# Altium schematic sheet ESSICC-GET-NMI.SchDoc(ESSICC-GET-NMI)
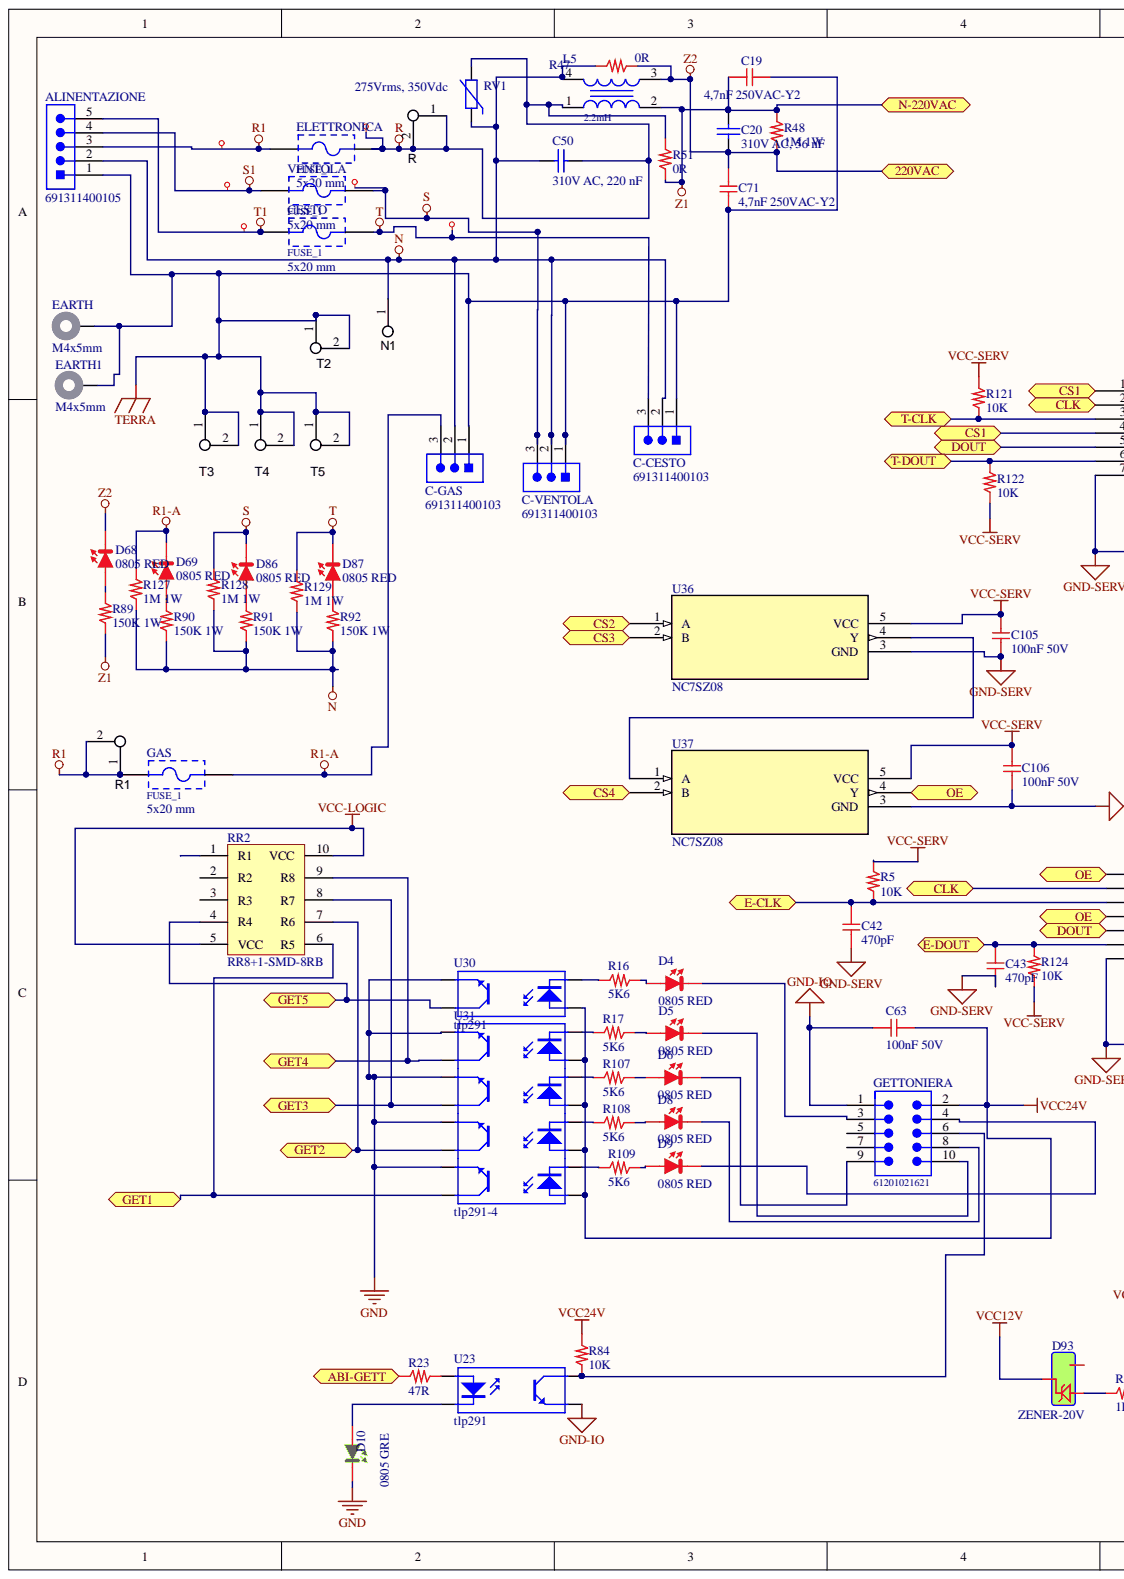
[diagram p0: ESSICC-GET-NMI.SchDoc, left]
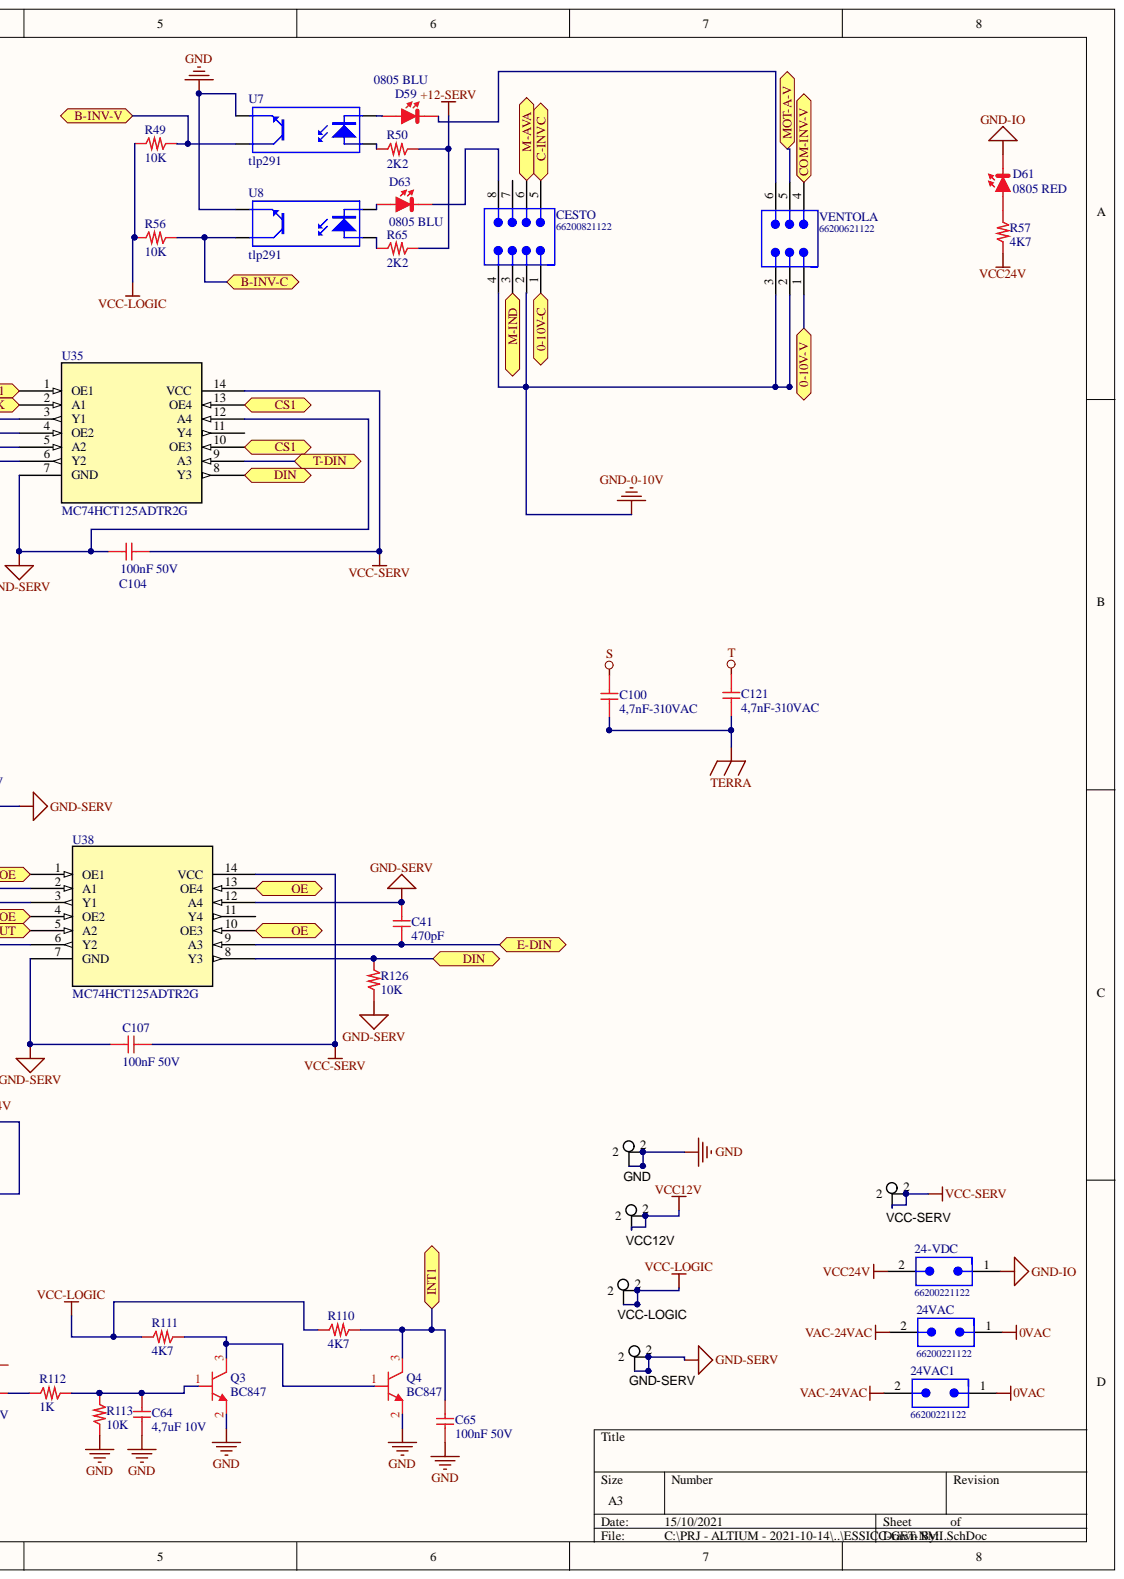
[diagram p1: ESSICC-GET-NMI.SchDoc, right]

## Parts
24-VDC  66200221122  [66200221122]
24VAC  66200221122  [66200221122]
24VAC1  66200221122  [66200221122]
ALINENTAZIONE  691311400105  [691311400105]
C19  4,7nF 250VAC-Y2  [WE-VD_10mm_820412511]
C20  310V AC, 56 nF  value 56 nF  [WCAP-FTXX_13x5x10_CS]
C41  470pF  [0603_c]
C42  470pF  [0603_c]
C43  470pF  [0603_c]
C50  310V AC, 220 nF  value 220 nF  [WCAP-FTXX_15x7x12.5_CS]
C63  100nF 50V  [0603_c]
C64  4,7uF 10V  [0603_c]
C65  100nF 50V  [0603_c]
C71  4,7nF 250VAC-Y2  [WE-VD_10mm_820412511]
C100  4,7nF-310VAC  [CY-PASSO15,24]
C104  100nF 50V  [0603_c]
C105  100nF 50V  [0603_c]
C106  100nF 50V  [0603_c]
C107  100nF 50V  [0603_c]
C121  4,7nF-310VAC  [CY-PASSO15,24]
C-CESTO  691311400103  [691311400103]
C-GAS  691311400103  [691311400103]
C-VENTOLA  691311400103  [691311400103]
CESTO  66200821122  [66200821122]
CESTO  FUSE_1  Fuse Size: 5x20 mm  [696108003002]
D4  0805 RED  [0805_Red]
D5  0805 RED  [0805_Red]
D6  0805 RED  [0805_Red]
D8  0805 RED  [0805_Red]
D9  0805 RED  [0805_Red]
D10  0805 GRE  [0805_Green]
D59  0805 BLU  [0805_Red]
D61  0805 RED  [0805_Red]
D63  0805 BLU  [0805_Red]
D68  0805 RED  [0805_Red]
D69  0805 RED  [0805_Red]
D86  0805 RED  [0805_Red]
D87  0805 RED  [0805_Red]
D93  ZENER-20V  [SOT23]
EARTH  M4x5mm  [9774050482]
EARTH1  M4x5mm  [9774050482]
ELETTRONICA  FUSE_1  [696108003002]
GAS  FUSE_1  Fuse Size: 5x20 mm  [696108003002]
GETTONIERA  61201021621  [61201021621]
GND  5012  [Keystone5012]  (value not drawn)
GND-SERV  5012  [Keystone5012]  (value not drawn)
L5  2.2mH  [WE-LF_Type SH]
N1  5012  [FASTOM-TERRA]  (value not drawn)
Q3  BC847  [SOT23]
Q4  BC847  [SOT23]
R  5012  [FASTOM-TERRA]  (value not drawn)
R1  5012  [FASTOM-TERRA]  (value not drawn)
R5  10K  [0603_R]
R16  5K6  [1206_R]
R17  5K6  [1206_R]
R23  47R  [0603_R]
R47  0R  [2512_R_Sens]
R48  1M 1W  [2512_R]
R49  10K  [0603_R]
R50  2K2  [1206_R]
R51  0R  [2512_R_Sens]
R56  10K  [0603_R]
R57  4K7  [1206_R]
R65  2K2  [1206_R]
R84  10K  [0603_R]
R89  150K 1W  [2512_R]
R90  150K 1W  [2512_R]
R91  150K 1W  [2512_R]
R92  150K 1W  [2512_R]
R107  5K6  [1206_R]
R108  5K6  [1206_R]
R109  5K6  [1206_R]
R110  4K7  [0603_R]
R111  4K7  [0603_R]
R112  1K  [0603_R]
R113  10K  [0603_R]
R121  10K  [0603_R]
R122  10K  [0603_R]
R124  10K  [0603_R]
R126  10K  [0603_R]
R127  1M 1W  [2512_R]
R128  1M 1W  [2512_R]
R129  1M 1W  [2512_R]
RR2  RR8+1-SMD-8RB  [RR8+1-SMD]
RV1  275Vrms, 350Vdc  DC Operating Voltage: 350Vdc  [WE-VD_7mm_820572711]
T2  5012  [FASTOM-TERRA]  (value not drawn)
T3  T  [FASTOM-TERRA]
T4  5012  [FASTOM-TERRA]  (value not drawn)
T5  5012  [FASTOM-TERRA]  (value not drawn)
U7  tlp291  [SO4_N]
U8  tlp291  [SO4_N]
U23  tlp291  [SO4_N]
U30  tlp291  [SO4_N]
U31  tlp291-4  [SO16_NO]
U35  MC74HCT125ADTR2G  [TSSOP14_4P50X5P10_ONS-M]
U36  NC7SZ08  [DBV5]
U37  NC7SZ08  [DBV5]
U38  MC74HCT125ADTR2G  [TSSOP14_4P50X5P10_ONS-M]
VCC12V  5012  [Keystone5012]  (value not drawn)
VCC-LOGIC  5012  [Keystone5012]  (value not drawn)
VCC-SERV  5012  [Keystone5012]  (value not drawn)
VENTOLA  66200621122  [66200621122]
VENTOLA  FUSE_1  Fuse Size: 5x20 mm  [696108003002]

## Ports
0-10V-C, 0-10V-V, 220VAC, ABI-GETT, B-INV-C, B-INV-V, C-INVC, CLK, COM-INV-V, CS1, CS2, CS3, CS4, DIN, DOUT, E-CLK, E-DIN, E-DOUT, GET1, GET2, GET3, GET4, GET5, INT1, M-AVA, M-IND, MOT-A-V, N-220VAC, OE, T-CLK, T-DIN, T-DOUT

## Nets
0VAC: 24VAC.1 24VAC1.1
+12-SERV: R50.2 R65.2
GND: C64.2 C65.2 D10.K GND.1 GND.2 Q3.2 Q4.2 R113.2 U7.3 U8.3 U30.3 U31.9 U31.11 U31.13 U31.15
GND-0-10V: CESTO.2 CESTO.4 VENTOLA.2 VENTOLA.3
GND-IO: 24-VDC.1 C63.1 D61.K GETTONIERA.1 U23.3
GND-SERV: C41.1 C42.2 C43.2 C104.1 C105.1 C106.1 C107.1 GND-SERV.1 GND-SERV.2 R126.2 U35.7(GND) U35.12(A4) U36.3(GND) U37.3(GND) U38.7(GND) U38.12(A4)
N: ALINENTAZIONE.2 C50.2 C-CESTO.2 C-GAS.2 C-VENTOLA.2 L5.4 N1.1 R47.2 R90.1 R91.1 R92.1 R127.2 R128.2 R129.2 RV1.1
R: C50.1 ELETTRONICA.2 L5.1 R.1 R.2 R51.1 RV1.2
R1: ALINENTAZIONE.3 ELETTRONICA.1 GAS.1 R1.1 R1.2
R1-A: C-GAS.3 D69.K GAS.2 R127.1
S: C100.1 C-VENTOLA.3 D86.K R128.1 VENTOLA.2
S1: ALINENTAZIONE.4 VENTOLA.1
T: C121.1 C-CESTO.3 CESTO.2 D87.K R129.1
T1: ALINENTAZIONE.5 CESTO.1
TERRA: ALINENTAZIONE.1 C19.2 C71.1 C100.2 C121.2 C-CESTO.1 C-GAS.1 C-VENTOLA.1 EARTH.1 EARTH1.1 T2.1 T2.2 T3.1 T3.2 T4.1 T4.2 T5.1 T5.2
VAC-24VAC: 24VAC.2 24VAC1.2
VCC12V: D93.3 VCC12V.1 VCC12V.2
VCC24V: 24-VDC.2 C63.2 GETTONIERA.2 R57.1 R84.1 U30.1 U31.1 U31.3 U31.5 U31.7
VCC-LOGIC: R49.1 R56.1 R110.2 R111.2 RR2.5(VCC) RR2.10(VCC) VCC-LOGIC.1 VCC-LOGIC.2
VCC-SERV: C104.2 C105.2 C106.2 C107.2 R5.1 R121.1 R122.2 R124.2 U35.14(VCC) U36.5(VCC) U37.5(VCC) U38.14(VCC) VCC-SERV.1 VCC-SERV.2
0-10V-C: CESTO.1 ; port 0-10V-C
0-10V-V: VENTOLA.1 ; port 0-10V-V
ABI-GETT: R23.1 ; port ABI-GETT
B-INV-C: R56.2 U8.4 ; port B-INV-C
B-INV-V: R49.2 U7.4 ; port B-INV-V
C-INVC: CESTO.5 ; port C-INVC
CLK: U35.2(A1) U38.2(A1) ; port CLK
COM-INV-V: VENTOLA.4 ; port COM-INV-V
CS1: U35.1(OE1) U35.4(OE2) U35.10(OE3) U35.13(OE4) ; port CS1
CS2: U36.1(A) ; port CS2
CS3: U36.2(B) ; port CS3
CS4: U37.2(B) ; port CS4
DIN: R126.1 U35.8(Y3) U38.8(Y3) ; port DIN
DOUT: U35.5(A2) U38.5(A2) ; port DOUT
E-CLK: C42.1 R5.2 U38.3(Y1) ; port E-CLK
E-DIN: C41.2 U38.9(A3) ; port E-DIN
E-DOUT: C43.1 R124.1 U38.6(Y2) ; port E-DOUT
GET1: RR2.6(R5) U31.16 ; port GET1
GET2: RR2.7(R6) U31.14 ; port GET2
GET3: RR2.8(R7) U31.12 ; port GET3
GET4: RR2.9(R8) U31.10 ; port GET4
GET5: RR2.4(R4) U30.4 ; port GET5
INT1: C65.1 Q4.3 R110.1 ; port INT1
M-AVA: CESTO.6 ; port M-AVA
M-IND: CESTO.3 ; port M-IND
MOT-A-V: VENTOLA.5 ; port MOT-A-V
OE: U37.4(Y) U38.1(OE1) U38.4(OE2) U38.10(OE3) U38.13(OE4) ; port OE
T-CLK: R121.2 U35.3(Y1) ; port T-CLK
T-DIN: U35.9(A3) ; port T-DIN
T-DOUT: R122.1 U35.6(Y2) ; port T-DOUT
Z1: C19.1 C20.1 L5.2 R48.1 R51.2 R89.1 ; port N-220VAC
Z2: C20.2 C71.2 D68.K L5.3 R47.1 R48.2 ; port 220VAC
NetC64_1: C64.1 Q3.1 R112.1 R113.1
NetCESTO_8: CESTO.8 D63.K
NetD4_A: D4.A R16.2
NetD4_K: D4.K GETTONIERA.3
NetD5_A: D5.A R17.2
NetD5_K: D5.K GETTONIERA.10
NetD6_A: D6.A R107.2
NetD6_K: D6.K GETTONIERA.9
NetD8_A: D8.A R108.2
NetD8_K: D8.K GETTONIERA.8
NetD9_A: D9.A R109.2
NetD9_K: D9.K GETTONIERA.4
NetD10_A: D10.A U23.2
NetD59_A: D59.A U7.2
NetD59_K: D59.K VENTOLA.6
NetD61_A: D61.A R57.2
NetD63_A: D63.A U8.2
NetD68_A: D68.A R89.2
NetD69_A: D69.A R90.2
NetD86_A: D86.A R91.2
NetD87_A: D87.A R92.2
NetD93_1: D93.1 R112.2
NetGETTONIERA_6: GETTONIERA.6 R84.2 U23.4
NetQ3_3: Q3.3 Q4.1 R111.1
NetR16_1: R16.1 U30.2
NetR17_1: R17.1 U31.8
NetR23_2: R23.2 U23.1
NetR50_1: R50.1 U7.1
NetR65_1: R65.1 U8.1
NetR107_1: R107.1 U31.6
NetR108_1: R108.1 U31.4
NetR109_1: R109.1 U31.2
NetU36_4: U36.4(Y) U37.1(A)

Not connected: CESTO 7; D93 2; GETTONIERA 5 7; RR2 1(R1) 2(R2) 3(R3); U35 11(Y4); U38 11(Y4)

## Library data of the parts
24-VDC 24VAC 24VAC1 = 66200221122: THT Male Header WR-MPC3, Vertical, Dual Row, pitch 3 mm, 2 pins; Working Voltage: 250 VAC; Pitch: 3 mm; Pin Number: 2; Contact Resistance: 10 mOhm; Published Date: September 2018; Part Number: 66200221122; Packaging: Tube; Orientation: Vertical; Operating Temperature: -40 to 105 degC; Mounting Technology: THT; Library Name: WR-MPC3.SchLib; Gender: Male; ComponentLink2URL: https://katalog.we-online.de/em/datasheet/6620xx21122.pdf; ComponentLink2Description: Datasheet; ComponentLink1URL: http://www.we-online.de; ComponentLink1Description: Manufacturer; Component Kind: Standard; Publisher: Wurth Elektronik
ALINENTAZIONE = 691311400105: WR-TBL Serie 3114 Close Vertical PCB Header, Pluggable, pitch 7.62mm, 5p; Working Voltage [UL]: 300VAC; Rated Current [UL]: 20A; Pin Number: 5; Modularity: No; Compliance: RoHS; Type: Vertical; Published Date: October 2019; Pitch: 7.62mm; PCB/Cable/Panel: PCB; Part Number: 691311400105; Packaging: Box; Operating Temperature: -40 to +105degC; Mounting Technology: THT; Library Name: WR-TBL_Pluggable PCB Header.SchLib; ComponentLink2URL: https://katalog.we-online.de/em/datasheet/691311400105.pdf; ComponentLink2Description: Datasheet; ComponentLink1URL: http://www.we-online.de; ComponentLink1Description: Manufacturer; Component Kind: Standard; Application: Pluggable; Publisher: Wurth Elektronik
C19 C71 = CY2: CAPACITOR Y2 275VAC-KEMET-C947U472MYVDBA7317; Produttore: ??; Cod. Interno: COCS557065000224; Component Kind: Standard; Cod. Produttore: ??; Library Name: HSW.SchLib
C20 = 890334023017CS: WCAP-FTXX Film Capacitors, 13x5x10mm, 310V AC, 56 nF; Value: 56 nF; Rated Voltage: 310V AC; Size: 13x5x10mm; Published Date: Sep. 2017; Part Number: 890334023017CS; Operating Temperature: -40 °C to +105 °C; Library Name: WCAP-FTXX.SchLib; ComponentLink2URL: http://katalog.we-online.de/pbs/datasheet/890334023017CS.pdf; ComponentLink2Description: Datasheet; ComponentLink1URL: http://www.we-online.de; ComponentLink1Description: Manufacturer; Component Kind: Standard; Publisher: Wurth Elektronik
C41-C43 = 470pF 50V: Cap X7R 470pF 50V; Produttore: ??; Cod. Interno: COCS??; Library Name: CapSMD0603.SchLib; Component Kind: Standard; Cod. Produttore: ??
C50 = 890334024002CS: WCAP-FTXX Film Capacitors, 15x7x12.5mm, 310V AC, 220 nF; Value: 220 nF; Rated Voltage: 310V AC; Size: 15x7x12.5mm; Published Date: Sep. 2017; Part Number: 890334024002CS; Operating Temperature: -40 °C to +105 °C; Library Name: WCAP-FTXX.SchLib; ComponentLink2URL: http://katalog.we-online.de/pbs/datasheet/890334024002CS.pdf; ComponentLink2Description: Datasheet; ComponentLink1URL: http://www.we-online.de; ComponentLink1Description: Manufacturer; Component Kind: Standard; Publisher: Wurth Elektronik
C63-C65 C104-C107 = 100NF 50V: Cap X7R 100nF 50V Flex Terminal; Produttore: Samsung; Cod. Produttore: CL10B104KB8SFNC; Cod. Interno: COCS50665050104; Library Name: CapSMD0603.SchLib; Component Kind: Standard; Cod. DigiKey: 1276-1936-2-ND
C100 C121 = CAP-Y-4,7nf 310vac-P15,24: CAP CLASSEY 310Vac 4,7nF-kemet; Produttore: WALSIN; Costo 1000: 0,0183; Costo 100: 0,0436; Cod. Produttore: 0603X225K100CT; Cod. Interno: COCS??; ComponentLink1URL: http://it.farnell.com/walsin/0603x225k100ct/cond-mlcc-x5r-2-2uf-10v-0603-bob/dp/2495422?st=2.2uf; ComponentLink1Description: FARNELL; Component Kind: Standard; Library Name: HSW.SchLib
C-CESTO C-GAS C-VENTOLA = 691311400103: WR-TBL Serie 3114 Close Vertical PCB Header, Pluggable, pitch 7.62mm, 3p; Working Voltage [UL]: 300VAC; Rated Current [UL]: 20A; Pin Number: 3; Modularity: No; Compliance: RoHS; Type: Vertical; Published Date: October 2019; Pitch: 7.62mm; PCB/Cable/Panel: PCB; Part Number: 691311400103; Packaging: Box; Operating Temperature: -40 to +105degC; Mounting Technology: THT; Library Name: WR-TBL_Pluggable PCB Header.SchLib; ComponentLink2URL: https://katalog.we-online.de/em/datasheet/691311400103.pdf; ComponentLink2Description: Datasheet; ComponentLink1URL: http://www.we-online.de; ComponentLink1Description: Manufacturer; Component Kind: Standard; Application: Pluggable; Publisher: Wurth Elektronik
CESTO = 66200821122: THT Male Header WR-MPC3, Vertical, Dual Row, pitch 3 mm, 8 pins; Working Voltage: 250 VAC; Pitch: 3 mm; Pin Number: 8; Contact Resistance: 10 mOhm; Published Date: September 2018; Part Number: 66200821122; Packaging: Tube; Orientation: Vertical; Operating Temperature: -40 to 105 degC; Mounting Technology: THT; Library Name: WR-MPC3.SchLib; Gender: Male; ComponentLink2URL: https://katalog.we-online.de/em/datasheet/6620xx21122.pdf; ComponentLink2Description: Datasheet; ComponentLink1URL: http://www.we-online.de; ComponentLink1Description: Manufacturer; Component Kind: Standard; Publisher: Wurth Elektronik
CESTO GAS VENTOLA = FUSE_1: WR-FSH Fuse Holder Blocks Fuse Holder Blocks Clip Cover for PCB; Published Date: August 2018; Part Number: 696108003002; Packaging: Box; Operating Temperature: -30 to 120 degC; Mounting Technology: THT; ComponentLink2URL: https://katalog.we-online.de/em/datasheet/696108003002.pdf; ComponentLink2Description: Datasheet; ComponentLink1URL: http://www.we-online.de; ComponentLink1Description: Manufacturer; Component Kind: Standard; Publisher: Wurth Elektronik; Library Name: HSW.SchLib
D4-D6 D8 D9 D59 D61 D63 D68 D69 D86 D87 = LED_ROSSO_0805: LED Rosso 0805; V: 2.0V; Produttore: WE; Luminosità: 150mcd; Cod. Produttore: 150080RS75000; Cod. Interno: LEDS 2AC080RS7500; Component Kind: Standard; Library Name: DiodiLED.SchLib
D10 = LED_Verde_0805: LED Verde 0805; V: 3.1V; Produttore: WE; Luminosità: 450mcd; Cod. Produttore: 150080GS75000; Cod. Interno: LEDS 9AC080GS7500; Component Kind: Standard; Library Name: DiodiLED.SchLib
D93 = CMPZ5241B: DIODE ZENER 11V 300MW SOT23; Vz: 10.45V - 11.55V; Produttore: Central Semiconductor; Cod. Interno: ZENS 620CMPZ5241B; Component Kind: Standard; Cod. Produttore: CMPZ5241B; Library Name: DiodiZENER.SchLib
EARTH EARTH1 = 9774050482: WA-SMSI SMT Steel Spacer, OD8.2mm, M4x5mm; Thread: M4; Surface: Tin; Outer Diameter: 8.2mm; Inner Diameter: 5.2mm; Published Date: September 2019; Part Number: 9774050482; Packaging: Bulk; Operating Temperature: -55 to +150degC; Mounting Technology: SMT; Material: Steel; Library Name: WA-SMSI_M4.SchLib; Length: 5mm; Inner Thread Length: -; ComponentLink2URL: https://katalog.we-online.com/em/datasheet/9774050482.pdf; ComponentLink2Description: Datasheet; ComponentLink1URL: http://www.we-online.de; ComponentLink1Description: Manufacturer; Component Kind: Standard; Publisher: Wurth Elektronik
ELETTRONICA = FUSE_1: WR-FSH Fuse Holder Blocks Fuse Holder Blocks Clip Cover for PCB; Fuse Size: 5x20 mm; Published Date: August 2018; Part Number: 696108003002; Packaging: Box; Operating Temperature: -30 to 120 degC; Mounting Technology: THT; ComponentLink2URL: https://katalog.we-online.de/em/datasheet/696108003002.pdf; ComponentLink2Description: Datasheet; ComponentLink1URL: http://www.we-online.de; ComponentLink1Description: Manufacturer; Component Kind: Standard; Publisher: Wurth Elektronik; Library Name: HSW.SchLib
GETTONIERA = 61201021621: Male Box Header WR-BHD, THT, Vertical, pitch 2.54 mm, 10 pins; Working Voltage: 250 VAC; Withstanding Voltage: 500 VAC; Pitch: 2.54 mm; Pin Number: 10; Contact Resistance: 20 mOhm; Published Date: August 2018; Part Number: 61201021621; Packaging: Tray; Orientation: Vertical; Operating Temperature: -40 to 125 degC; Mounting Technology: THT; Gender: Male; ComponentLink2URL: https://katalog.we-online.de/em/datasheet/6120xx21621.pdf; ComponentLink2Description: Datasheet; ComponentLink1URL: http://www.we-online.de; ComponentLink1Description: Manufacturer; Component Kind: Standard; Publisher: Wurth Elektronik; Library Name: CONN-FLAT.SchLib
GND GND-SERV VCC12V VCC-LOGIC VCC-SERV = 5012_1: Test Point, Multipurpose, White, TH; Manufacturer: Keystone; ContactFinish: Silver; CompType: Test Point Loop; SymbolVersion: 2008-04-04; Supplier Part Number 2: 534-5012; Supplier Part Number 1: 36-5012-ND; Supplier 2: Mouser; Supplier 1: Digi-Key; RoHS: Y; PartNumber: 5012; PackageReference: White Multipurpose Testpoint; MountType: TH; LatestRevisionDate: 2017-01-19; ComponentLink1URL: http://www.keyelco.com/userAssets/file/M60-2p60.pdf; ComponentLink1Description: Datasheet URL; Component Kind: Standard; Color: White; Publisher: Texas Instruments; Library Name: HSW.SchLib
L5 = 7446622002: WE-LF Common Mode Power Line Choke, Type SH, 2.2mH, 2A, 250V; Rated Voltage: 250V (AC); Insulation Test Voltage: 1500V (AC); DC Resistance max.: 0.1Ohm; Size: Type SH; Rated Current: 2A; Published Date: March 2019; Part Number: 7446622002; Packaging: Tray and Carton; Operating Temperature: -40 to +125degC; Mounting Technology: THT; Library Name: WE-LF_Type SH.SchLib; Inductance: 2.2mH; ComponentLink2URL: https://katalog.we-online.com/pbs/datasheet/7446622002.pdf; ComponentLink2Description: Datasheet; ComponentLink1URL: http://www.we-online.de; ComponentLink1Description: Manufacturer; Component Kind: Standard; Publisher: Wurth Elektronik
N1 R R1 T2 T4 T5 = TERRA: Test Point, Multipurpose, White, TH; Manufacturer: Keystone; ContactFinish: Silver; CompType: Test Point Loop; SymbolVersion: 2008-04-04; Supplier Part Number 2: 534-5012; Supplier Part Number 1: 36-5012-ND; Supplier 2: Mouser; Supplier 1: Digi-Key; RoHS: Y; PartNumber: 5012; PackageReference: White Multipurpose Testpoint; MountType: TH; LatestRevisionDate: 2017-01-19; ComponentLink1URL: http://www.keyelco.com/userAssets/file/M60-2p60.pdf; ComponentLink1Description: Datasheet URL; Component Kind: Standard; Color: White; Publisher: Texas Instruments; Library Name: HSW.SchLib
Q3 Q4 = MMBT3904LT1G: NPN Si Digital Transistor; Vce: 40V; Vcb: 60V; Ptot: 300mW; Produttore: ON Semiconductor; Ic: 200mA; Ft: 300MHz; Cod. Interno: TRXS 110MMBT3904LT1G; Component Kind: Standard; Cod. Produttore: MMBT3904LT1G; Library Name: TransitorSMD.SCHLIB
R5 R110-R113 R121 R122 R124 R126 = 2K7: Res Film Spesso 1/16W 2K7 5%; Produttore: VISHAY; Cod. Produttore: CRCW06032K70JNEA; Cod. Interno: RESS4166556B0272; Library Name: ResSMD0603.SchLib; Component Kind: Standard
R16 R17 R50 R65 R107-R109 = 2K2: 2K2 1206 1/4W; Library Name: ResSMD1206.SchLib; Component Kind: Standard; Case: 1206
R23 = 47R: Res Film Spesso 1/16W 47R 5%; Produttore: ??; Cod. Interno: RESS4166556B0047??; Library Name: ResSMD0603.SchLib; Component Kind: Standard; Cod. Produttore: ??
R47 R51 = 0.0015R 1%: Lega Metallica 2W 0.0015R 1%; Produttore: Riedon / WELWYN; Cod. Produttore: CSR2512C0R0015F / ULR2-R0015FT2; Cod. Interno: RESS 4590320A1R5m; Library Name: ResSMD2512.SchLib; Component Kind: Standard; Cod. Farnell: 1292490RL; Cod. DigiKey: 696-1184-1-ND
R48 R127-R129 = 150K 5% 1W 500V: 1M 5% 1W 500V; Produttore: Vishay; Cod. Produttore: CRCW2512150KJNEG; Cod. Interno: RESS 4190510A0154; Library Name: ResSMD2512.SchLib; Component Kind: Standard; Cod. Farnell: 1886539
R49 R56 R84 = 10K: Res Film Spesso 1/16W 10K 5%; Produttore: ??; Cod. Interno: RESS4166556B0103??; Library Name: ResSMD0603.SchLib; Component Kind: Standard; Cod. Produttore: ??
R57 = 10K: 4K7 1206 1/4W; Library Name: ResSMD1206.SchLib; Component Kind: Standard; Case: 1206
R89-R92 = 150K 5% 1W 500V: Produttore: Vishay; Cod. Produttore: CRCW2512150KJNEG; Cod. Interno: RESS 4190510A0154; Library Name: ResSMD2512.SchLib; Description: 150K 5% 1W 500V; Component Kind: Standard; Cod. Farnell: 1886539
RV1 = 820572711: WE-VD Disk Varistor, size 7mm, 275Vrms, 350Vdc; Power Dissipation: 0.25W; Energy Absorbtion: 33J; Clamping Voltage: 710V; (Reverse) Peak Pulse Current: 1200A; (Reverse) Breakdown Voltage: 430V; (Channel) Input Capacitance: 105pF; Size: 7mm; Published Date: March 2019; Part Number: 820572711; Packaging: Tape and Reel; Operating Temperature: -40 to +85degC; Mounting Technology: THT; ComponentLink2URL: https://katalog.we-online.com/pbs/datasheet/820572711.pdf; ComponentLink2Description: Datasheet; ComponentLink1URL: http://www.we-online.de; ComponentLink1Description: Manufacturer; Component Kind: Standard; AC Operating Voltage: 275Vrms; Publisher: Wurth Elektronik; Library Name: MOV_7mm.SchLib
T3 = TERRA: Test Point, Multipurpose, White, TH; PartNumber: 5012; Manufacturer: Keystone; ContactFinish: Silver; CompType: Test Point Loop; SymbolVersion: 2008-04-04; Supplier Part Number 2: 534-5012; Supplier Part Number 1: 36-5012-ND; Supplier 2: Mouser; Supplier 1: Digi-Key; RoHS: Y; PackageReference: White Multipurpose Testpoint; MountType: TH; LatestRevisionDate: 2017-01-19; ComponentLink1URL: http://www.keyelco.com/userAssets/file/M60-2p60.pdf; ComponentLink1Description: Datasheet URL; Component Kind: Standard; Color: White; Publisher: Texas Instruments; Library Name: HSW.SchLib
U7 U8 U23 U30 = tlp291: 4-Pin Phototransistor Optocoupler; Published: 24-Mar-1999; PackageReference: DIP-4; LatestRevisionNote: Sch pins 3 & 4 Swapped.; LatestRevisionDate: 04-Mar-2005; DatasheetVersion: Nov-2003; Component Kind: Standard; Publisher: Altium Limited; Library Name: HSW.SchLib
U31 = tlp291_4: 4-Pin Phototransistor Optocoupler; Published: 24-Mar-1999; PackageReference: DIP-4; LatestRevisionNote: Sch pins 3 & 4 Swapped.; LatestRevisionDate: 04-Mar-2005; DatasheetVersion: Nov-2003; Component Kind: Standard; Publisher: Altium Limited; Library Name: HSW.SchLib
U35 U38 = MC74HCT125ADTR2G: BUFFER; Vendor: ON Semiconductor; SourceLibrary: ONSemi_2017-12-12_5; Type: DEV; RefDes: RefDes; Manufacturer_Part_Number: MC74HCT125ADTR2G; Component Kind: Standard; Library Name: HSW.SchLib; Copyright: Copyright (C) 2016 Accelerated Designs. All rights reserved
U36 U37 = NC7SZ08: Single 2-Input Positive-AND Gate; Manufacturer_Part_Number: SN74AHC1G08DBVR; GENERIC_PART_NUMBER: SN74AHC1G08; Vendor: Texas Instruments; PIN_COUNT: 5; PACKAGE_DESIGNATOR: DBV; INDUSTRY_STD_PKG_TYPE: SOT-23; FAMILY_NAME: LL AND GATE; Type: DEV; RefDes: RefDes; Manufacturer: Texas Instruments; Datasheet_URL: http://www.ti.com/lit/gpn/SN74AHC1G08; Component Kind: Standard; Library Name: HSW.SchLib; Copyright: Copyright (C) 2018 Accelerated Designs. All rights reserved
VENTOLA = 66200621122: THT Male Header WR-MPC3, Vertical, Dual Row, pitch 3 mm, 6 pins; Working Voltage: 250 VAC; Pitch: 3 mm; Pin Number: 6; Contact Resistance: 10 mOhm; Published Date: September 2018; Part Number: 66200621122; Packaging: Tube; Orientation: Vertical; Operating Temperature: -40 to 105 degC; Mounting Technology: THT; Library Name: WR-MPC3.SchLib; Gender: Male; ComponentLink2URL: https://katalog.we-online.de/em/datasheet/6620xx21122.pdf; ComponentLink2Description: Datasheet; ComponentLink1URL: http://www.we-online.de; ComponentLink1Description: Manufacturer; Component Kind: Standard; Publisher: Wurth Elektronik
RR2 = RR8+1-SMD-8RB: Component Kind: Standard; Library Name: HSW.SchLib
